# Altium schematic sheet AD936X_Digital.SchDoc(AD936X_Digital)
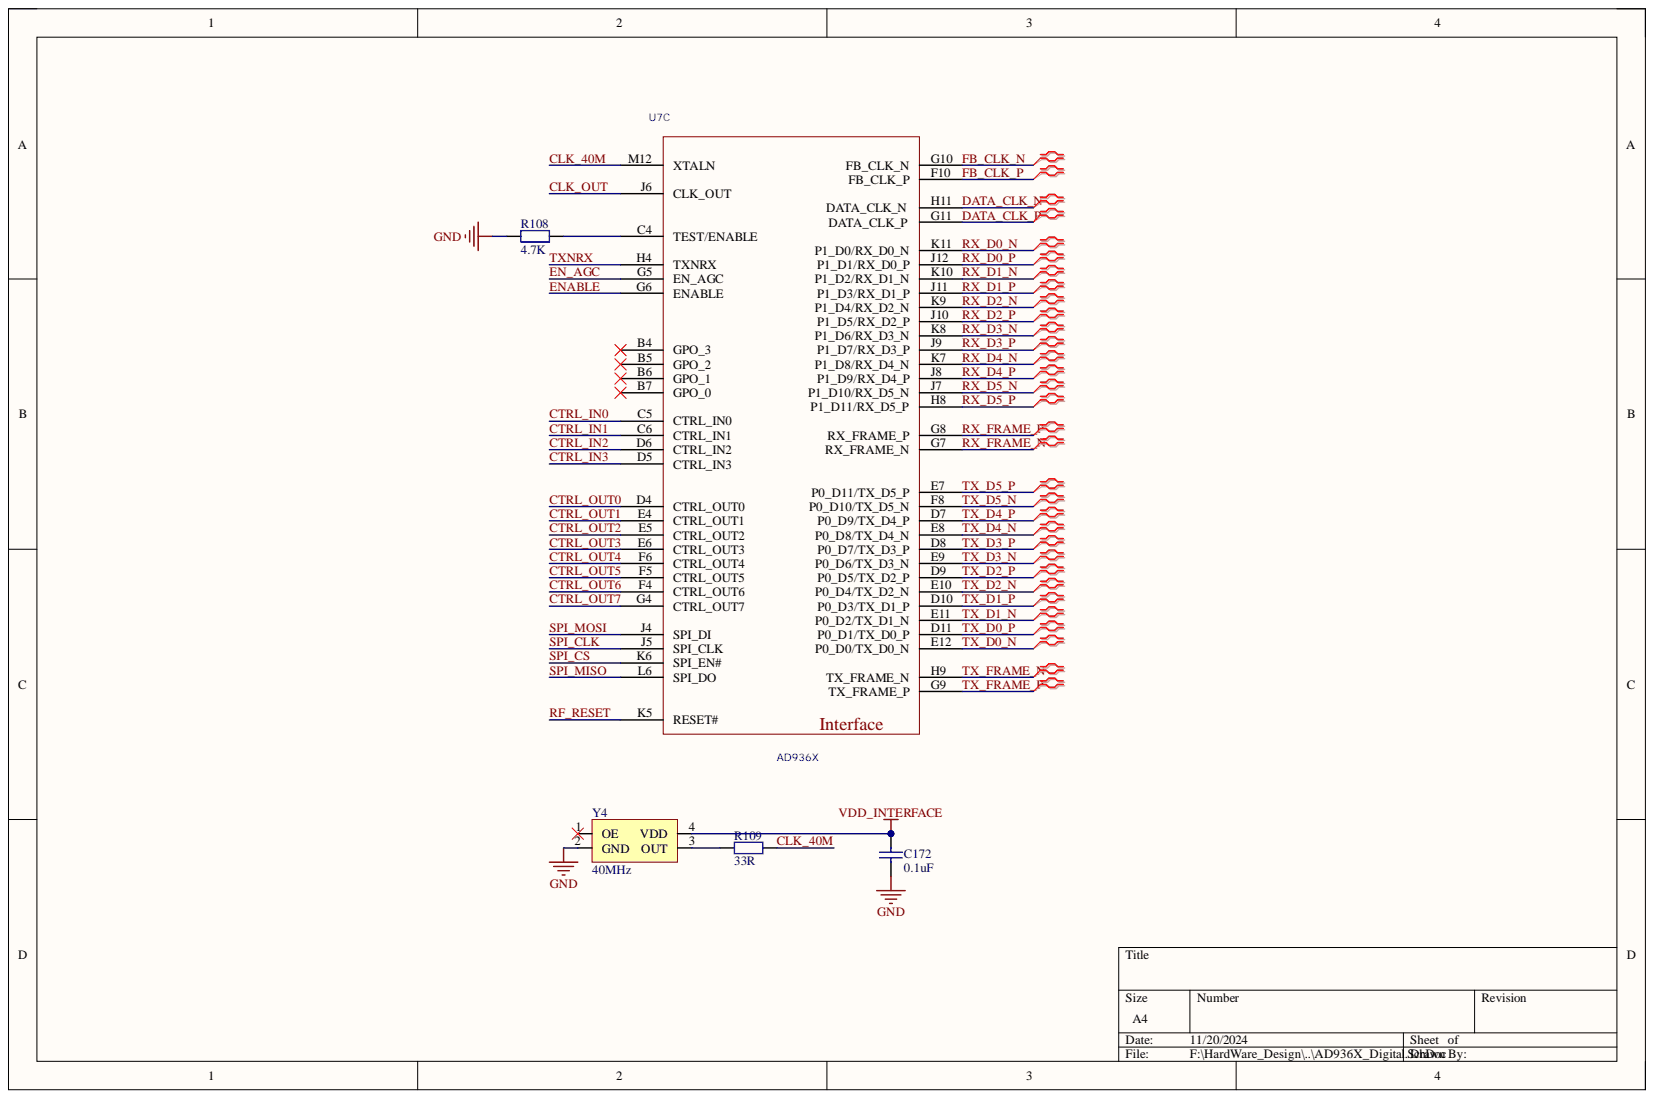
[diagram p0: AD936X_Digital.SchDoc]

## Parts
C172  0.1uF  [C 0402_L]
R108  4.7K  [R 0402_L]
R109  33R  [R 0402_L]
U7  AD936X  [BGA144C80P12X12_1000X1000X170]  (part C)
Y4  40M  value 40MHz  [OSC 3225-4P]

## Nets
GND: C172.1 R108.1 Y4.2(GND)
VDD_INTERFACE: C172.2 Y4.4(VDD)
CLK_40M: R109.2 U7.M12(XTALN)
CLK_OUT: U7.J6(CLK_OUT)
CTRL_IN0: U7.C5(CTRL_IN0)
CTRL_IN1: U7.C6(CTRL_IN1)
CTRL_IN2: U7.D6(CTRL_IN2)
CTRL_IN3: U7.D5(CTRL_IN3)
CTRL_OUT0: U7.D4(CTRL_OUT0)
CTRL_OUT1: U7.E4(CTRL_OUT1)
CTRL_OUT2: U7.E5(CTRL_OUT2)
CTRL_OUT3: U7.E6(CTRL_OUT3)
CTRL_OUT4: U7.F6(CTRL_OUT4)
CTRL_OUT5: U7.F5(CTRL_OUT5)
CTRL_OUT6: U7.F4(CTRL_OUT6)
CTRL_OUT7: U7.G4(CTRL_OUT7)
DATA_CLK_N: U7.H11(DATA_CLK_N)
DATA_CLK_P: U7.G11(DATA_CLK_P)
EN_AGC: U7.G5(EN_AGC)
ENABLE: U7.G6(ENABLE)
FB_CLK_N: U7.G10(FB_CLK_N)
FB_CLK_P: U7.F10(FB_CLK_P)
RF_RESET: U7.K5(RESET#)
RX_D0_N: U7.K11(P1_D0/RX_D0_N)
RX_D0_P: U7.J12(P1_D1/RX_D0_P)
RX_D1_N: U7.K10(P1_D2/RX_D1_N)
RX_D1_P: U7.J11(P1_D3/RX_D1_P)
RX_D2_N: U7.K9(P1_D4/RX_D2_N)
RX_D2_P: U7.J10(P1_D5/RX_D2_P)
RX_D3_N: U7.K8(P1_D6/RX_D3_N)
RX_D3_P: U7.J9(P1_D7/RX_D3_P)
RX_D4_N: U7.K7(P1_D8/RX_D4_N)
RX_D4_P: U7.J8(P1_D9/RX_D4_P)
RX_D5_N: U7.J7(P1_D10/RX_D5_N)
RX_D5_P: U7.H8(P1_D11/RX_D5_P)
RX_FRAME_N: U7.G7(RX_FRAME_N)
RX_FRAME_P: U7.G8(RX_FRAME_P)
SPI_CLK: U7.J5(SPI_CLK)
SPI_CS: U7.K6(SPI_EN#)
SPI_MISO: U7.L6(SPI_DO)
SPI_MOSI: U7.J4(SPI_DI)
TX_D0_N: U7.E12(P0_D0/TX_D0_N)
TX_D0_P: U7.D11(P0_D1/TX_D0_P)
TX_D1_N: U7.E11(P0_D2/TX_D1_N)
TX_D1_P: U7.D10(P0_D3/TX_D1_P)
TX_D2_N: U7.E10(P0_D4/TX_D2_N)
TX_D2_P: U7.D9(P0_D5/TX_D2_P)
TX_D3_N: U7.E9(P0_D6/TX_D3_N)
TX_D3_P: U7.D8(P0_D7/TX_D3_P)
TX_D4_N: U7.E8(P0_D8/TX_D4_N)
TX_D4_P: U7.D7(P0_D9/TX_D4_P)
TX_D5_N: U7.F8(P0_D10/TX_D5_N)
TX_D5_P: U7.E7(P0_D11/TX_D5_P)
TX_FRAME_N: U7.H9(TX_FRAME_N)
TX_FRAME_P: U7.G9(TX_FRAME_P)
TXNRX: U7.H4(TXNRX)
NetR108_2: R108.2 U7.C4(TEST/ENABLE)
NetR109_1: R109.1 Y4.3(OUT)

Not connected: U7 B4(GPO_3) B5(GPO_2) B6(GPO_1) B7(GPO_0); Y4 1(OE)

## Library data of the parts
C172 = Cap: 贴片电容; Component Kind: Standard; Library Name: A_Schlib.SchLib; value: 0.1uF
R108 R109 = Res: 通用; Component Kind: Standard; Library Name: A_Schlib.SchLib
  R108: value: 4.7K
  R109: value: 33R
U7 = AD936X: 射频捷变收发器; Component Kind: Standard; Library Name: A_Schlib.SchLib
Y4 = XATLS-4P: 4脚贴片有源晶振; Component Kind: Standard; Library Name: A_Schlib.SchLib; 封装库来自淘宝卖家 ID：黄晶宝石: https://shop463601444.taobao.com
